# Altium schematic sheet Sheet4.SchDoc(Sheet4)
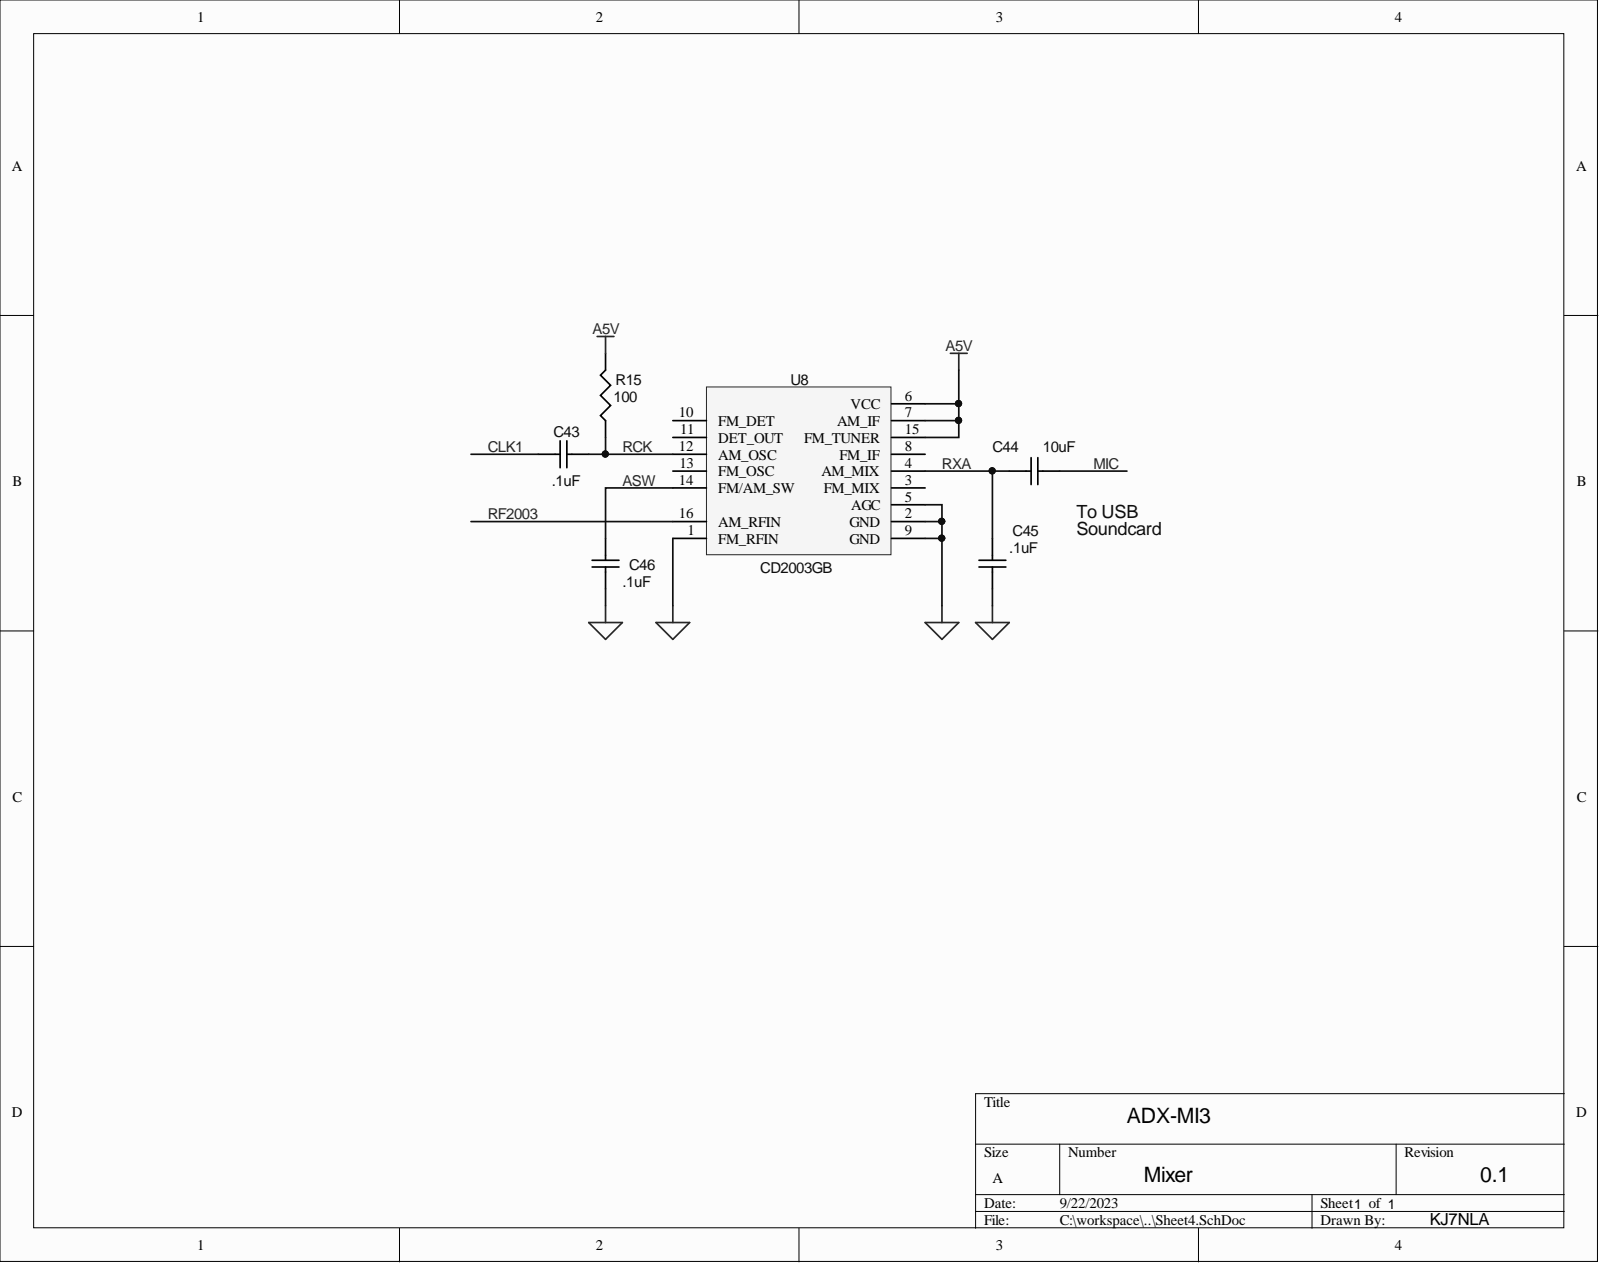
[diagram p0: Sheet4.SchDoc]

## Parts
C43  .1uF  [3-0603]
C44  10uF  [3-0603]
C45  .1uF  [3-0603]
C46  .1uF  [3-0603]
R15  100  [3-0603]
U8  CD2003GB  [SOP-16]

## Nets
A5V: R15.2 U8.6(VCC) U8.7(AM_IF) U8.15(FM_TUNER)
GND: C45.1 C46.1 U8.1(FM_RFIN) U8.2(GND) U8.5(AGC) U8.9(GND)
ASW: C46.2 U8.14(FM/AM_SW)
CLK1: C43.1
MIC: C44.2
RCK: C43.2 R15.1 U8.12(AM_OSC)
RF2003: U8.16(AM_RFIN)
RXA: C44.1 C45.2 U8.4(AM_MIX)

Not connected: U8 3(FM_MIX) 8(FM_IF) 10(FM_DET) 11(DET_OUT) 13(FM_OSC)

## Library data of the parts
C43-C46 = Cap: Supplier Part Number 1: NA; Supplier 1: Digi-Key; Component Kind: Standard; Library Name: usdx.SchLib
  C43 C45 C46: Value: .1uF; JLCC Part Number: C113803
  C44: Value: 10uF; JLCC Part Number: C344022
R15 = Resistor: Value: 100; Supplier Part Number 1: NA; Supplier 1: Digi-Key; JLCC Part Number: C22775; Component Kind: Standard; Library Name: usdx.SchLib
U8 = CD2003GB: Supplier Part Number 1: NA; Supplier 1: Digi-Key; JLCC Part Number: NA; Component Kind: Standard; Library Name: usdx.SchLib
